# Altium schematic sheet TSAL.SchDoc(TSAL)
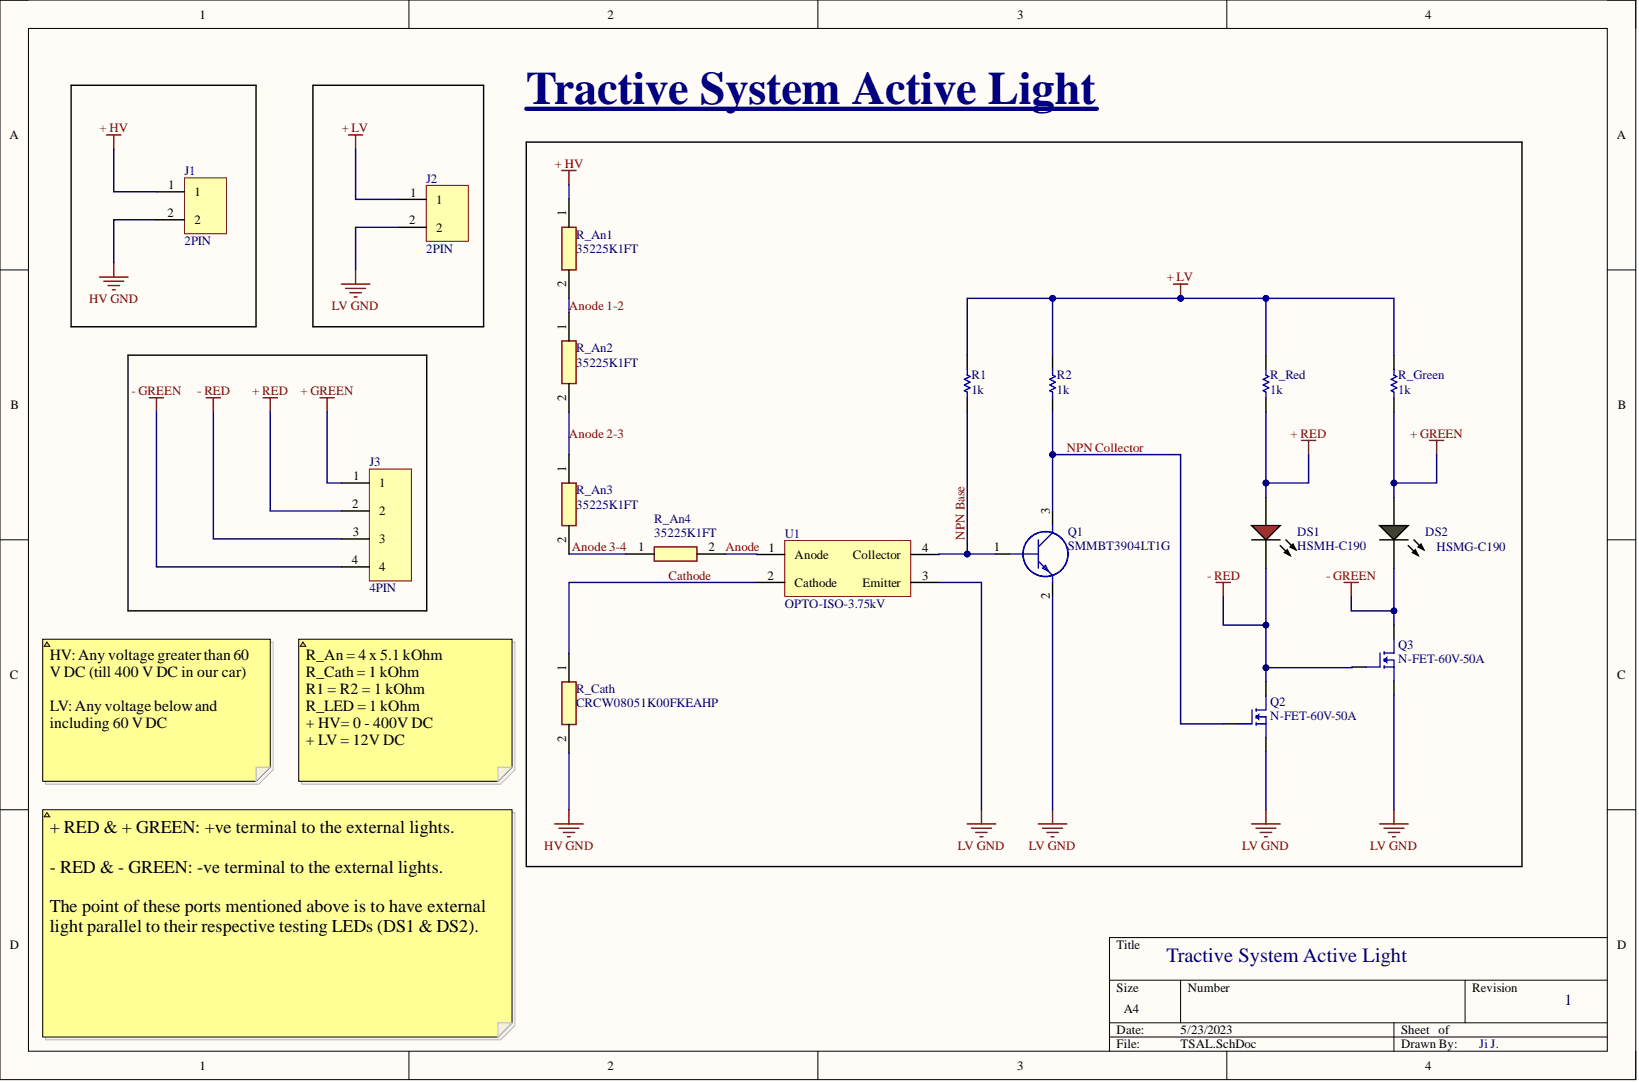
[diagram p0: TSAL.SchDoc]

## Parts
DS1  HSMH-C190
DS2  HSMG-C190
J1  2PIN
J2  2PIN
J3  4PIN
Q1  SMMBT3904LT1G
Q2  N-FET-60V-50A
Q3  N-FET-60V-50A
R1  value 1k  (hidden comment: Resistor 1k +/-1% 1206 250 mW)
R2  value 1k  (hidden comment: Resistor 1k +/-1% 1206 250 mW)
R_An1  35225K1FT
R_An2  35225K1FT
R_An3  35225K1FT
R_An4  35225K1FT
R_Cath  CRCW08051K00FKEAHP
R_Green  value 1k  (hidden comment: Resistor 1k +/-1% 1206 250 mW)
R_Red  value 1k  (hidden comment: Resistor 1k +/-1% 1206 250 mW)
U1  OPTO-ISO-3.75kV

## Nets
+ GREEN: DS2.1 J3.1 R_Green.1
+ HV: J1.1 R_An1.1
+ LV: J2.1 R1.2 R2.2 R_Green.2 R_Red.2
+ RED: DS1.1 J3.2 R_Red.1
- GREEN: DS2.2 J3.4 Q3.2
- RED: DS1.2 J3.3 Q2.2 Q3.1
HV GND: J1.2 R_Cath.2
LV GND: J2.2 Q1.2 Q2.3 Q3.3 U1.3(Emitter)
Anode: R_An4.2 U1.1(Anode)
Anode 1-2: R_An1.2 R_An2.1
Anode 2-3: R_An2.2 R_An3.1
Anode 3-4: R_An3.2 R_An4.1
Cathode: R_Cath.1 U1.2(Cathode)
NPN Base: Q1.1 R1.1 U1.4(Collector)
NPN Collector: Q1.3 Q2.1 R2.1

## Library data of the parts
DS1 = CMP-007-00014-2: LED Uni-Color Red, 65 mW, 20 mA, -40 to 85 degC, 2-Pin SMD, RoHS, Tape and Reel; Manufacturer: Avago; Forward Current: 20mA; Forward Voltage: 1.8V; Lens Style: Diffused; Luminous Intensity: 17mcd; Power: 78mW; Viewing Angle: 170degrees; Case/Package: 0603; Colour: Red; Component Kind: Standard; Datasheet URL: http://docs.avagotech.com/docs/AV02-0551EN; Datasheet Version: Mar-12; Illumination Colour: Red; Manufacturer URL: http://www.avagotech.com/; Max Operating Temperature: 85°C; Min Operating Temperature: -40°C; Mounting Technology: SM; Nominal Vf: 1.8V; Package Description: 2-Pin Surface Mount Device, Body 1.6 x 0.8 mm, Height 0.9 mm; Package Quantity: 4000; Package Reference: HSMX-C190; Packaging: TapeandReel; Pins: 2; RoHS Compliant: True; Library Name: SFU Team Phantom
DS2 = CMP-007-00013-2: LED Uni-Color Green, 52 mW, 20 mA, -40 to 85 degC, 2-Pin SMD, RoHS, Tape and Reel; Manufacturer: Avago; Forward Current: 20mA; Forward Voltage: 2.2V; Lens Style: Diffused; Luminous Intensity: 15mcd; Power: 52mW; Viewing Angle: 170degrees; Case/Package: 0603; Colour: Green; Component Kind: Standard; Datasheet URL: http://docs.avagotech.com/docs/AV02-0551EN; Datasheet Version: Mar-12; Illumination Colour: Green; Manufacturer URL: http://www.avagotech.com/; Max Operating Temperature: 85°C; Min Operating Temperature: -40°C; Mounting Technology: SM; Nominal Vf: 2.2V; Package Description: 2-Pin Surface Mount Device, Body 1.6 x 0.8 mm, Height 0.9 mm; Package Quantity: 4000; Package Reference: HSMX-C190; Packaging: TapeandReel; Pins: 2; RoHS Compliant: True; Library Name: SFU Team Phantom
J1 J2 = CMP-011-000000-1: CONN HEADER R/A 2POS 2.54MM; Manufacturer: Molex; Manufacturer Part Number: 0705530106; Connector Type: Male Pin; Contact Resistance: 30mR; Number of Contacts: 2; Color: Black; Component Kind: Standard; ComponentLink1Description: http://www.molex.com/webdocs/datasheets/pdf/en-us/0705530106_PCB_HEADERS.pdf?src-supplier=Avnet+Asia; ComponentLink1URL: http://www.molex.com/webdocs/datasheets/pdf/en-us/0705530106_PCB_HEADERS.pdf?src-supplier=Avnet+Asia; ComponentLink2Description: https://www.molex.com/pdm_docs/ps/PS-70400.pdf?src-supplier=Digi-Key; ComponentLink2URL: https://www.molex.com/pdm_docs/ps/PS-70400.pdf?src-supplier=Digi-Key; Contact Material: Bronze; Contact Mating Length: 0.24inch; Contact Plating: Gold; Depth: 13.59mm; Design Item ID: 2PIN; Housing Color: Black; Housing Material: Polyester; Length: 7.37mm; Max Operating Temperature: 105°C; Min Operating Temperature: -40°C; Mount: Through Hole; Number of Positions: 2; Number of Rows: 1; Orientation: Right Angle; Packaging: Rail/Tube; Pitch: 2.54mm; Pricing 1: 1=1.38, 10=1.25, 100=1.071, 500=0.8925, 1000=0.765, 5000=0.6783, 10000=0.663 (USD); Source: Connectors.SchLib; Stock 1: 2224; Termination: Solder; Library Name: SFU Team Phantom
J3 = CMP-011-000002-1: CONN HEADER R/A 4POS 2.54MM; Manufacturer: Molex; Manufacturer Part Number: 70553-0003; Approvals: UL,CSA; Contact Resistance: 30mR; Current Rating: 3A; Gender: Male; Glow Wire Compliant: Non-compliant; Insulation Resistance: 1GR; Number of Circuits: 4; Voltage Rating: 250V; Color: Black; Component Kind: Standard; ComponentLink1Description: http://www.molex.com/webdocs/datasheets/pdf/en-us/0705530003_PCB_HEADERS.pdf?src-supplier=Mouser; ComponentLink1URL: http://www.molex.com/webdocs/datasheets/pdf/en-us/0705530003_PCB_HEADERS.pdf?src-supplier=Mouser; ComponentLink2Description: http://www.jameco.com/Jameco/Products/ProdDS/884758.pdf?src-supplier=Jameco; ComponentLink2URL: http://www.jameco.com/Jameco/Products/ProdDS/884758.pdf?src-supplier=Jameco; ComponentLink3Description: http://uk.rs-online.com/webdocs/13c4/0900766b813c4977.pdf?src-supplier=RSComponents; ComponentLink3URL: http://uk.rs-online.com/webdocs/13c4/0900766b813c4977.pdf?src-supplier=RSComponents; Contact Material: Bronze,Copper Alloy; Contact Plating: Gold; Design Item ID: 4PIN; ELV: Compliant; Flammability Rating: UL94 V-0; Housing Color: Black; Housing Material: Polycyclohexylenedimethylene; Lead Free: Lead Free; Material: Brass; Max Operating Temperature: 105°C; Min Operating Temperature: -40°C; Mount: Through Hole; Number of Contacts: 4; Number of Positions: 4; Number of Rows: 1; Orientation: Right Angle; Package Quantity: 1680; Packaging: Rail/Tube; Pitch: 2.54mm; Pricing 1: 1=1.05, 10=0.927, 100=0.7995, 500=0.65412, 1000=0.58144, 5000=0.50876, 10000=0.48332 (USD); REACH SVHC: Unknown; Source: Connectors.SchLib; Stock 1: 4238; Termination: Through Hole; Voltage Rating (DC): 250V; Library Name: SFU Team Phantom
Q1 = SMMBT3904LT1G: Transistor BJT NPN; Manufacturer_Name: onsemi; Arrow Part Number: SMMBT3904LT1G; Arrow Price/Stock: https://www.arrow.com/en/products/smmbt3904lt1g/on-semiconductor; Component Kind: Standard; Datasheet Link: https://www.onsemi.com/pub/Collateral/MMBT3904LT1-D.PDF; Height: 1.11mm; Manufacturer_Part_Number: SMMBT3904LT1G; Mouser Part Number: 863-SMMBT3904LT1G; Mouser Price/Stock: https://www.mouser.co.uk/ProductDetail/onsemi/SMMBT3904LT1G?qs=SHm1gYoUFFxP0E8RxmhUgw%3D%3D
Q2 Q3 = CMP-029-000004-1: Trans MOSFET N-CH 60V 50A 3-Pin(2+Tab) TO-252; Manufacturer: Infineon; Manufacturer Part Number: IPD50N06S4L12ATMA2; Fall Time: 5ns; Gate to Source Voltage (Vgs): 16V; Input Capacitance: 2.89nF; Max Power Dissipation: 50W; Rds On Max: 12mR; Rise Time: 2ns; Turn-Off Delay Time: 25ns; Turn-On Delay Time: 6ns; Case/Package: TO-252-3; Component Kind: Standard; Continuous Drain Current (ID): 50A; Design Item ID: N-FET-60V-50A; Drain to Source Voltage (Vdss): 60V; Max Dual Supply Voltage: 60V; Max Operating Temperature: 175°C; Min Operating Temperature: -55°C; Mount: Surface Mount; Number of Pins: 3; Packaging: Tape and Reel; Pricing 1: 1=0.92, 10=0.806, 25=0.7572, 100=0.5497, 250=0.53012, 500=0.45928, 1000=0.39088 (USD); Source: Transistors.SchLib; Stock 1: 3187; Library Name: SFU Team Phantom
R1 R2 R_Green R_Red = CMP-009-00011-5: Chip Resistor, 1 KOhm, +/- 1%, 0.25 W, -55 to 155 degC, 1206 (3216 Metric); Power: 250mW; Voltage Rating: 200V; Case/Package: 1206; Component Kind: Standard; Max Operating Temperature: 155°C; Min Operating Temperature: -55°C; Mounting Technology: SM; Pins: 2; RoHS Compliant: True; Tolerance: 1%; Library Name: SFU Team Phantom
R_An1-R_An4 = 35225K1FT: Resistor; Manufacturer_Name: TE Connectivity; Arrow Part Number: 35225K1FT; Arrow Price/Stock: https://www.arrow.com/en/products/35225k1ft/te-connectivity; Component Kind: Standard; Datasheet Link: https://datasheet.datasheetarchive.com/originals/distributors/DKDS-22/429594.pdf; Height: 1.2mm; Manufacturer_Part_Number: 35225K1FT; Mouser Part Number: 279-35225K1FT; Mouser Price/Stock: https://www.mouser.co.uk/ProductDetail/TE-Connectivity-Holsworthy/35225K1FT/?qs=Rv6LVDxB0ZoNLPcdjuYSCg%3D%3D
R_Cath = CRCW08051K00FKEAHP: Resistor; Manufacturer_Name: Vishay; Arrow Part Number: CRCW08051K00FKEAHP; Arrow Price/Stock: https://www.arrow.com/en/products/crcw08051k00fkeahp/vishay?region=nac; Component Kind: Standard; Datasheet Link: http://uk.rs-online.com/web/p/products/8123435; Height: 0.5mm; Manufacturer_Part_Number: CRCW08051K00FKEAHP; Mouser Part Number: 71-CRCW08051K00FKEAH; Mouser Price/Stock: https://www.mouser.co.uk/ProductDetail/Vishay-Dale/CRCW08051K00FKEAHP?qs=FlFvgi4rxBy%252Bn2DMV%2FkIbA%3D%3D
U1 = CMP-022-000001-1: OPTOISO 3.75KV TRANS 4MINIFLAT; Manufacturer: Broadcom Avago; Manufacturer Part Number: HCPL-181-00BE; Approvals: UL,CSA,VDE; Collector Emitter Saturation Voltage: 200mV; Collector Emitter Voltage (VCEO): 80V; Current Transfer Ratio: 260%; Fall Time: 18000ns; Forward Current: 50mA; Forward Voltage: 1.4V; Input Current: 20mA; Max Power Dissipation: 170mW; Number of Channels: 1; Reverse Breakdown Voltage: 6V; Case/Package: SMD/SMT; Component Kind: Standard; ComponentLink1Description: http://www.farnell.com/datasheets/317140.pdf?src-supplier=Farnell; ComponentLink1URL: http://www.farnell.com/datasheets/317140.pdf?src-supplier=Farnell; ComponentLink2Description: http://uk.rs-online.com/webdocs/0db8/0900766b80db8748.pdf?src-supplier=RSComponents; ComponentLink2URL: http://uk.rs-online.com/webdocs/0db8/0900766b80db8748.pdf?src-supplier=RSComponents; ComponentLink3Description: http://www1.futureelectronics.com/doc/AVAGO%20TECHNOLOGIES/HCPL-181-00BE.pdf?src-supplier=Future+Electronics; ComponentLink3URL: http://www1.futureelectronics.com/doc/AVAGO%20TECHNOLOGIES/HCPL-181-00BE.pdf?src-supplier=Future+Electronics; ComponentLink4Description: https://www.verical.com/server-webapp/html/getDatasheet?partID=142397&src-supplier=Verical; ComponentLink4URL: https://www.verical.com/server-webapp/html/getDatasheet?partID=142397&src-supplier=Verical; ComponentLink5Description: http://www.farnell.com/datasheets/317140.pdf?src-supplier=Element14; ComponentLink5URL: http://www.farnell.com/datasheets/317140.pdf?src-supplier=Element14; ComponentLink6Description: https://www.distrelec.biz/Web/Downloads/_t/ds/HCPL-181_eng_tds.pdf?mime=application%2Fpdf&src-supplier=Distrelec; ComponentLink6URL: https://www.distrelec.biz/Web/Downloads/_t/ds/HCPL-181_eng_tds.pdf?mime=application%2Fpdf&src-supplier=Distrelec; Design Item ID: OPTO-ISO-3.75kV; Isolation Voltage: 3.75kV; Lead Free: Lead Free; Max Collector Current: 50mA; Max Input Current: 50mA; Max Operating Temperature: 100°C; Max Output Voltage: 80V; Min Operating Temperature: -55°C; Mount: Surface Mount; Number of Circuits: 1; Number of Elements: 1; Number of Pins: 4; Output Current per Channel: 50mA; Package Quantity: 1; Packaging: Tape and Reel; Power Dissipation: 170mW; Pricing 1: 1=0.56, 10=0.433, 100=0.2778, 500=0.23886, 1000=0.18443 (USD); Radiation Hardening: No; REACH SVHC: No SVHC; Rise Time: 18000ns; Source: ICs.SchLib; Stock 1: 94429; Library Name: SFU Team Phantom
